# Altium schematic sheet PSU - Power Supply.SchDoc
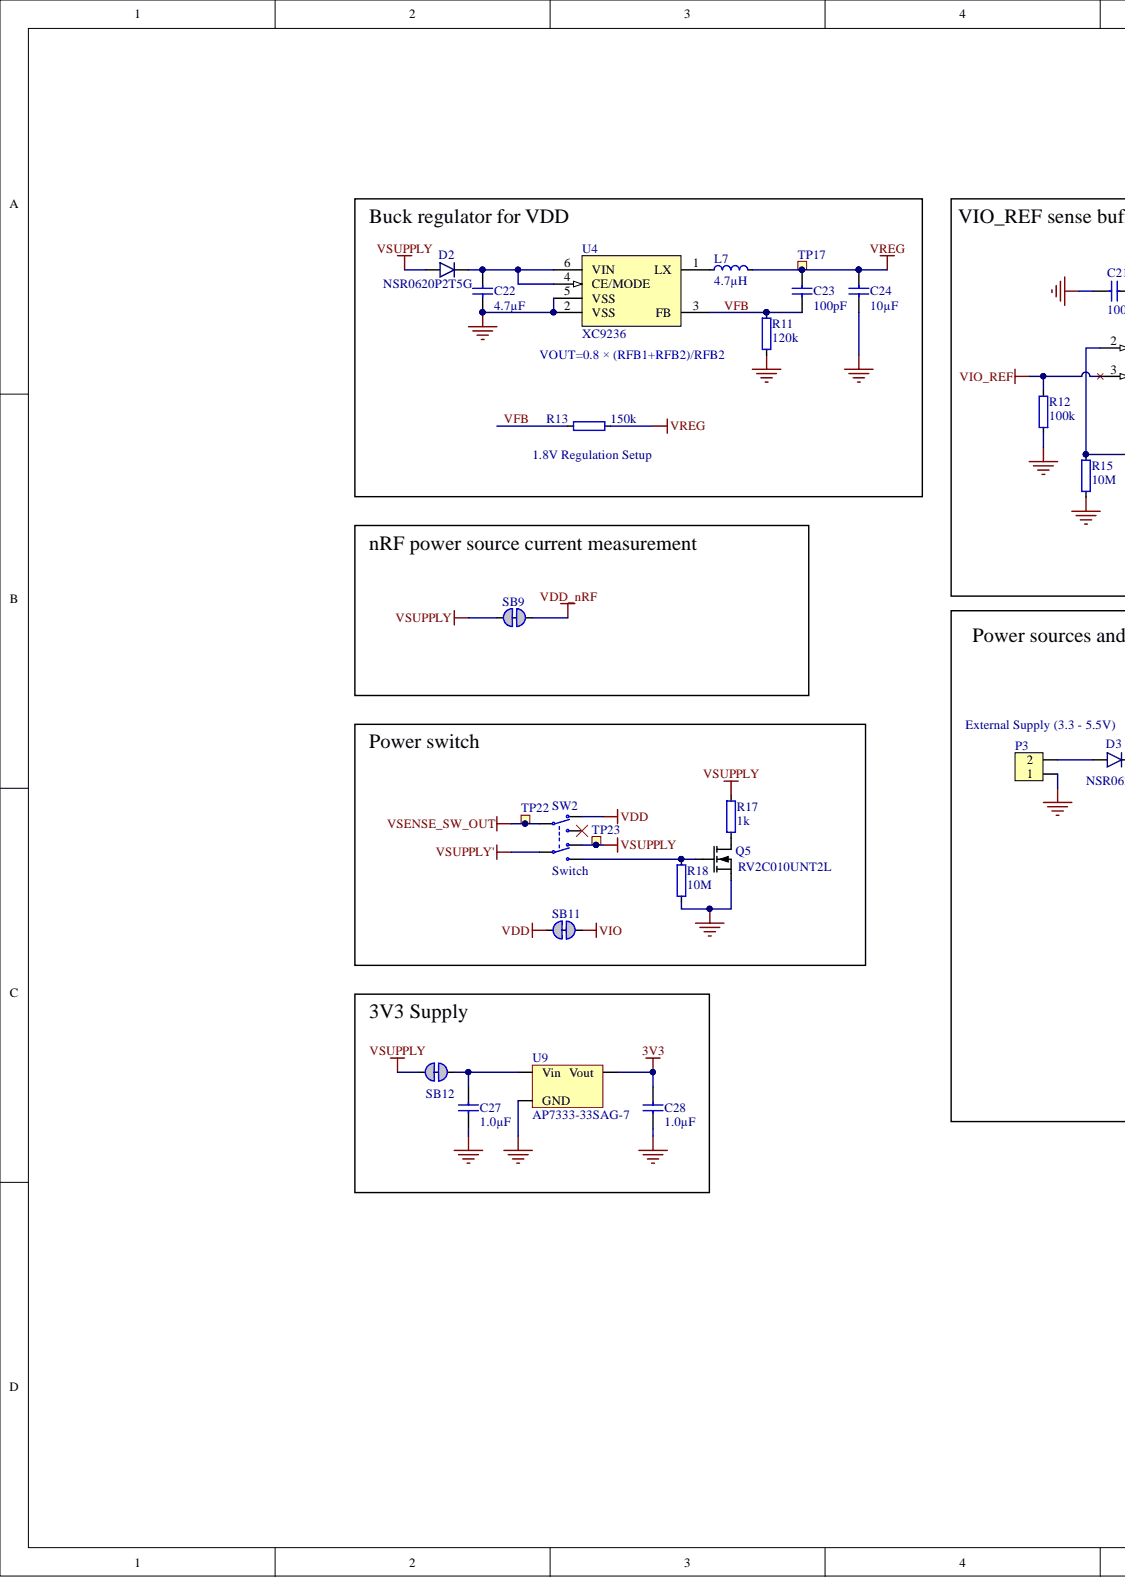
[diagram p0: PSU - Power Supply.SchDoc, left]
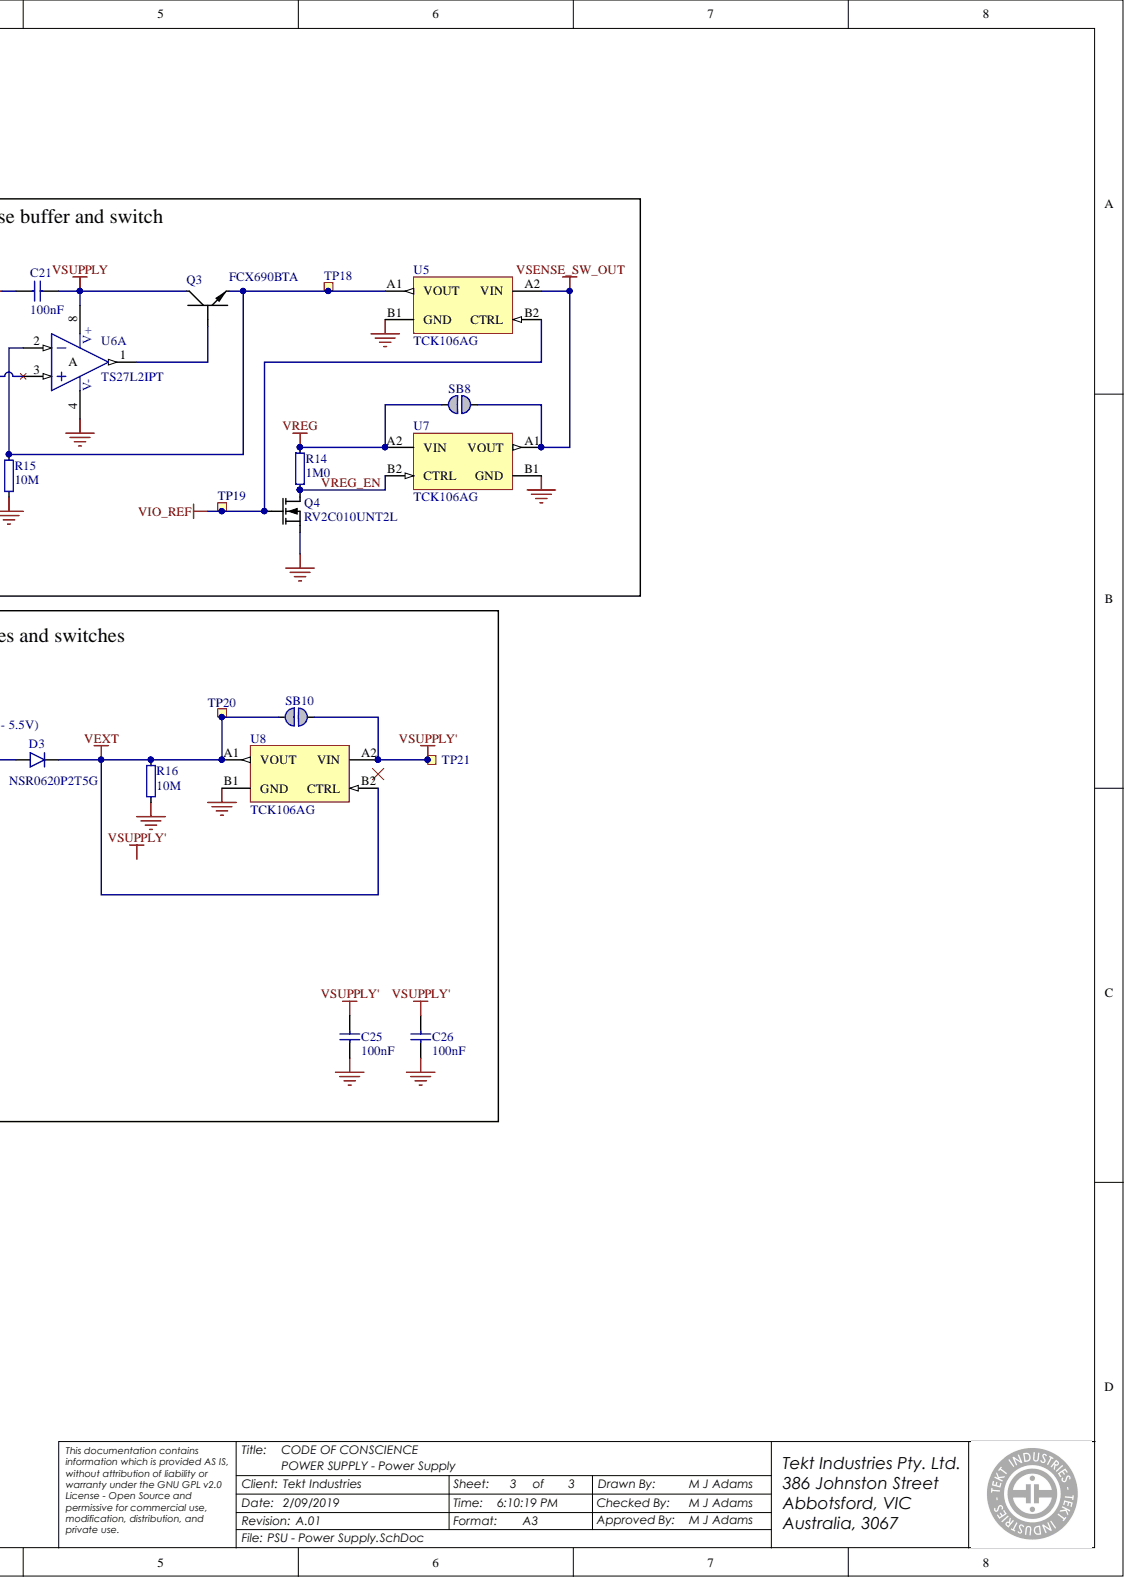
[diagram p1: PSU - Power Supply.SchDoc, right]

## Parts
C21  100nF  [CAPC0603X03L_C]
C22  4.7µF  [CAPC1005X04L]
C23  100pF  [CAPC0603X03L_C]
C24  10µF  [CAPC1608X06L]
C25  100nF  [CAPC0603X03L_C]
C26  100nF  [CAPC0603X03L_C]
C27  1.0µF  [CAPC0603X03L_C]
C28  1.0µF  [CAPC0603X03L_C]
D2  NSR0620P2T5G  [SODFL100X40-2N]
D3  NSR0620P2T5G  [SODFL100X40-2N]
L7  4.7µH  [TY-NR3015]
P3  Pin List 1x2, Angled  [LIST_ANG_1x2-SMD-2.54MM]  (value not drawn)
Q3  FCX690BTA  [SOT89-150P409X160-3N]
Q4  RV2C010UNT2L  [DFN65P100X40-3L]
Q5  RV2C010UNT2L  [DFN65P100X40-3L]
R11  120k  [RESC0603X03L_C]
R12  100k  [RESC0603X03L_C]
R13  150k  [RESC0603X03L_C]
R14  1M0  [RESC0603X03L_C]
R15  10M  [RESC0603X03L_C]
R16  10M  [RESC0603X03L_C]
R17  1k  [RESC0603X03L_C]
R18  10M  [RESC0603X03L_C]
SB8  Solderbridge  [Solderbridge]  (value not drawn)  (no BOM)
SB9  Solderbridge  [Solderbridge_Shorted]  (value not drawn)
SB10  Solderbridge  [Solderbridge]  (value not drawn)  (no BOM)
SB11  Solderbridge  [Solderbridge_Shorted]  (value not drawn)
SB12  Solderbridge  [Solderbridge_Shorted]  (value not drawn)
SW2  Switch  [Switch-Slide-RA-J-Lead-DPDT-8.5x3.5mm]
TP17  Ø1.5mm,SMD  [TP_SMD_Ø1.5mm]  (value not drawn)  (no BOM)
TP18  Ø1.5mm,SMD  [TP_SMD_Ø1.5mm]  (value not drawn)  (no BOM)
TP19  Ø1.5mm,SMD  [TP_SMD_Ø1.5mm]  (value not drawn)  (no BOM)
TP20  Ø1.5mm,SMD  [TP_SMD_Ø1.5mm]  (value not drawn)  (no BOM)
TP21  Ø1.5mm,SMD  [TP_SMD_Ø1.5mm]  (value not drawn)  (no BOM)
TP22  Ø1.5mm,SMD  [TP_SMD_Ø1.5mm]  (value not drawn)  (no BOM)
TP23  Ø1.5mm,SMD  [TP_SMD_Ø1.5mm]  (value not drawn)  (no BOM)
U4  XC9236  [USP-6C]
U5  TCK106AG  [TCK106AG]
U6  TS27L2IPT  [TSOP65P640X120-8N]  (part A)
U7  TCK106AG  [TCK106AG]
U8  TCK106AG  [TCK106AG]
U9  AP7333-33SAG-7  [SOT-23A]

## Nets
3V3: C28.1 U9.2
GND: C21.1 C22.1 C24.1 C25.2 C26.1 C27.2 C28.2 P3.1 Q4.2 Q5.2 R11.1 R12.2 R15.1 R16.1 R18.1 U4.2(VSS) U4.5(VSS) U4.7 U5.B1(GND) U6.4 U7.B1(GND) U8.B1(GND) U9.3
VDD: SB11.2 SW2.3
VDD_nRF: SB9.2
VEXT: D3.1 R16.2 SB10.2 TP20.1 U8.A1(VOUT) U8.B2(CTRL)
VIO: SB11.1
VIO_REF: Q4.1 R12.1 TP19.1 U5.B2(CTRL) U6.3
VREG: C23.2 C24.2 L7.2 R13.2 R14.2 SB8.2 TP17.1 U7.A2(VIN)
VSENSE_SW_OUT: SB8.1 SW2.2 TP22.1 U5.A2(VIN) U7.A1(VOUT)
VSUPPLY: C21.2 D2.2 Q3.2 R17.2 SB9.1 SB12.2 SW2.6 TP23.1 U6.8
VSUPPLY': C25.1 C26.2 SB10.1 SW2.5 TP21.1 U8.A2(VIN)
VFB: C23.1 R11.2 R13.1 U4.3(FB)
VREG_EN: Q4.3 R14.1 U7.B2(CTRL)
NetC22_2: C22.2 D2.1 U4.4(CE/MODE) U4.6(VIN)
NetC27_1: C27.1 SB12.1 U9.1
NetD3_2: D3.2 P3.2
NetL7_1: L7.1 U4.1(LX)
NetQ3_1: Q3.1 U6.1
NetQ3_3: Q3.3 R15.2 TP18.1 U5.A1(VOUT) U6.2
NetQ5_1: Q5.1 R18.2 SW2.4
NetQ5_3: Q5.3 R17.1

Not connected: SW2 1

## Library data of the parts
C21 C25 C26 = C0201-100n: Capacitor, X5R, ±10%; Manufacturer: Murata Electronics North America; Manufacturer Part No.: GRM033R61C104KE84D; Part number IPS: 00000001248; Artikkelnr Noca: N.A.; Database Table Name: Capacitors$; Note: 16V; Component Kind: Standard; ComponentLink1Description: Datasheet; ComponentLink2Description: Supplier link; Footprint Doc: 0201; LatestRevisionDate: 2017-11-09; Library Name: Nordic Passive DB.DbLib; Part Number: C0201-100n; Pin Count: 2; Published: 2014-11-13; Supplier: Digi-Key; Supplier Part No.: 490-9985-1-ND; Value: 100nF; Publisher: Nordic Semiconductor
C22 = C0402-4µ7: Capacitor, X5R, ±20%; Manufacturer: Murata Electronics North America; Manufacturer Part No.: ZRB15XR61A475KE01D; Part number IPS: 00000001251; Artikkelnr Noca: 20039482; Database Table Name: Capacitors$; Note: 10V; Component Kind: Standard; ComponentLink1Description: Datasheet; ComponentLink2Description: Supplier link; Footprint Doc: 0402; LatestRevisionDate: 2017-11-09; Library Name: Nordic Passive DB.DbLib; Part Number: C0402-4µ7; Pin Count: 2; Published: 2009-05-08; Supplier: Digi-Key; Supplier Part No.: 490-13252-1-ND; Value: 4.7µF; Publisher: Nordic Semiconductor
C23 = C0201-100p: Capacitor, NP0, ±2%; Manufacturer: Murata Electronics North America; Manufacturer Part No.: GRM0335C1E101JA01D; Part number IPS: 00000007853; Artikkelnr Noca: N.A.; Database Table Name: Capacitors$; Note: 25V; Component Kind: Standard; ComponentLink1Description: Datasheet; ComponentLink2Description: Supplier link; Footprint Doc: 0201; LatestRevisionDate: 2017-11-09; Library Name: Nordic Passive DB.DbLib; Part Number: C0201-100p; Pin Count: 2; Published: 2014-11-13; Supplier: Digi-Key; Supplier Part No.: 490-3160-1-ND; Value: 100pF; Publisher: Nordic Semiconductor
C24 = C0603-10µF-25V: Capacitor, 0603, 25V, X5R; Manufacturer: Murata Electronics North America; Manufacturer Part No.: ZRB18AR61E106ME01L; Part number IPS: N.A.; Artikkelnr Noca: 20039436; Database Table Name: Capacitors$; Component Kind: Standard; ComponentLink1Description: Datasheet; ComponentLink2Description: Supplier link; Footprint Doc: 0603; LatestRevisionDate: 2015-02-25; Library Name: Nordic Passive DB.DbLib; Note: 25V; Part Number: C0603-10µF-25V; Pin Count: 2; Published: 2015-02-25; Supplier: Digi-Key; Supplier Part No.: 490-10991-1-ND; Value: 10µF; Publisher: Nordic Semiconductor
C27 C28 = C0201-1µ0: Capacitor, X5R, ±10%; Manufacturer: Samsung Electro-Mechanics; Manufacturer Part No.: CL03A105KP3NSNC; Artikkelnr Noca: N.A.; Database Table Name: Capacitors$; Note: 10V; Component Kind: Standard; ComponentLink1Description: Datasheet; ComponentLink2Description: Supplier link; Footprint Doc: 0201; LatestRevisionDate: 2016-08-22; Library Name: Nordic Passive DB.DbLib; Part Number: C0201-1µ0; Part number IPS: N.A.; Pin Count: 2; Published: 2009-05-08; Supplier: Digi-Key; Supplier Part No.: 1276-6795-1-ND; Value: 1.0µF; Publisher: Nordic Semiconductor
D2 D3 = NSR0620P2T5G: 20V schottky barrier diode, Vf 350 mV @ 100 mA, Ir 2.0 µA @ 10 V, If 500 mA; Manufacturer: ON Semiconductor; Part number IPS: N.A.; Artikkelnr Noca: 10029169; Database Table Name: Semiconductors$; Component Kind: Standard; ComponentLink1Description: Datasheet; ComponentLink2Description: Supplier link; Footprint Doc: SOD-923; HelpURL: http://www.onsemi.com/pub_link/Collateral/NSR0620P2-D.PDF; LatestRevisionDate: 2016-07-07; Library Name: Nordic Discrete DB.DbLib; Manufacturer Part No.: NSR0620P2T5G; Part Number: NSR0620P2T5G; Pin Count: 2; Published: 2016-07-07; Supplier: Digi-Key; Supplier Part No.: NSR0620P2T5GOSCT-ND; Value: NSR0620P2T5G; Publisher: Nordic Semiconductor
L7 = NR3015-4µ7-1.04A-144mOhm: Ferrite Inductor, Shielded, 1,04A, 144mOhm; Manufacturer: Taiyo Yuden; Manufacturer Part No.: NR3015T4R7M; Part number IPS: N.A.; Artikkelnr Noca: 33029551; Database Table Name: Inductors$; Component Kind: Standard; ComponentLink1Description: Datasheet; ComponentLink2Description: Supplier link; Footprint Doc: 1212; HelpURL: http://www.yuden.co.jp/ut/product/category/inductor/NR3015T4R7M.pdf; LatestRevisionDate: 2014-01-22; Library Name: Nordic Passive DB.DbLib; Part Number: NR3015-4µ7-1.04A-144mOhm; Pin Count: 2; Published: 2014-01-22; Supplier: Digi-Key; Supplier 2: Mouser; Supplier Part No.: 587-1649-1-ND; Supplier Part Number 2: 963-NR3015T4R7M; Value: 4.7µH; Publisher: Nordic Semiconductor
P3 = Pin List Ang 1x2 SMD, 2.54mm: Pin List 1x2, 2.54mm (100mil), Right Angled, SMD; Manufacturer: Samtec Inc.; Manufacturer Part No.: TSM-102-01-L-SH-A; Part number IPS: N.A.; Artikkelnr Noca: 55010023; Database Table Name: Connectors$; Component Kind: Standard; ComponentLink1Description: Datasheet; ComponentLink2Description: Supplier link; Footprint Doc: LIST_ANG_1x2-SMD-2.54MM; HelpURL: \\hizz\pro\app9717\Datasheet\connectores\W-CON\1125B-11XXXXZXXXXX.pdf; LatestRevisionDate: 2016-05-26; Library Name: Nordic Passive DB.DbLib; Part Number: Pin List Ang 1x2 SMD, 2.54mm; Pin Count: 2; Published: 2016-01-22; Supplier: Digi-Key; Supplier 2: Samtec; Supplier Part No.: SAM10266-ND; Supplier Part Number 2: TSM-102-01-L-SH-A; Value: Pin List 1x2, Angled; Publisher: Nordic Semiconductor
Q3 = FCX690BTA: 45V NPN high gain power transistor; Manufacturer: Diodes Incorporated; Part number IPS: N.A.; Artikkelnr Noca: 35010019; Database Table Name: Semiconductors$; Component Kind: Standard; ComponentLink1Description: Datasheet; ComponentLink2Description: Supplier link; Footprint Doc: SOT-89; HelpURL: http://www.diodes.com/_files/datasheets/FCX690B.pdf; LatestRevisionDate: 2016-07-07; Library Name: Nordic Discrete DB.DbLib; Manufacturer Part No.: FCX690BTA; Part Number: FCX690BTA; Pin Count: 3; Published: 2016-07-07; Supplier: Digi-Key; Supplier Part No.: FCX690BCT-ND; Value: FCX690BTA; Publisher: Nordic Semiconductor
Q4 Q5 = RV2C010UNT2L: N-Channel MOSFET, 20V, 1A, 470mOhm, 400mW; Manufacturer: Rohm Semiconductor; Part number IPS: N.A.; Artikkelnr Noca: 35010020; Database Table Name: Semiconductors$; Component Kind: Standard; ComponentLink1Description: Datasheet; ComponentLink2Description: Supplier link; Footprint Doc: DFN-3; HelpURL: http://rohmfs.rohm.com/en/products/databook/datasheet/discrete/transistor/mosfet/rv2c010unt2l-e.pdf; LatestRevisionDate: 2016-08-09; Library Name: Nordic Discrete DB.DbLib; Manufacturer Part No.: RV2C010UNT2L; Part Number: RV2C010UNT2L; Pin Count: 3; Published: 2016-08-09; Supplier: Digi-Key; Supplier 2: Mouser; Supplier Part No.: RV2C010UNT2LCT-ND; Supplier Part Number 2: 755-RV2C010UNT2L; Value: RV2C010UNT2L; Publisher: Nordic Semiconductor
R11 = R0201-120k: Resistor, ±1%, 0.05W; Manufacturer: Yageo; Manufacturer Part No.: RC0201FR-07120KL; Artikkelnr Noca: N.A.; Database Table Name: Resistors$; Note: Chip Res 1%; Component Kind: Standard; ComponentLink1Description: Datasheet; ComponentLink2Description: Supplier link; Footprint Doc: 0201; LatestRevisionDate: 2014-11-13; Library Name: Nordic Passive DB.DbLib; Part Number: R0201-120k; Part number IPS: N.A.; Pin Count: 2; Published: 2014-11-13; Supplier: Digi-Key; Supplier Part No.: 311-120KMCT-ND; Value: 120k; Publisher: Nordic Semiconductor
R12 = R0201-100k: Resistor, ±1%, 0.05W; Manufacturer: Yageo; Manufacturer Part No.: RC0201FR-07100KL; Artikkelnr Noca: N.A.; Database Table Name: Resistors$; Note: Chip Res 1%; Component Kind: Standard; ComponentLink1Description: Datasheet; ComponentLink2Description: Supplier link; Footprint Doc: 0201; LatestRevisionDate: 2014-11-13; Library Name: Nordic Passive DB.DbLib; Part Number: R0201-100k; Part number IPS: N.A.; Pin Count: 2; Published: 2014-11-13; Supplier: Digi-Key; Supplier Part No.: 311-100KMCT-ND; Value: 100k; Publisher: Nordic Semiconductor
R13 = R0201-150k: Resistor, ±1%, 0.05W; Manufacturer: Stackpole Electronics Inc; Manufacturer Part No.: RMCF0201FT150K; Artikkelnr Noca: N.A.; Database Table Name: Resistors$; Note: Chip Res 1%; Component Kind: Standard; ComponentLink1Description: Datasheet; ComponentLink2Description: Supplier link; Footprint Doc: 0201; LatestRevisionDate: 2014-11-13; Library Name: Nordic Passive DB.DbLib; Part Number: R0201-150k; Part number IPS: N.A.; Pin Count: 2; Published: 2014-11-13; Supplier: Digi-Key; Supplier Part No.: RMCF0201FT150KCT-ND; Value: 150k; Publisher: Nordic Semiconductor
R14 = R0201-1M0: Resistor, ±1%, 0.05W; Manufacturer: Yageo; Manufacturer Part No.: RC0201FR-071ML; Artikkelnr Noca: N.A.; Database Table Name: Resistors$; Note: Chip Res 1%; Component Kind: Standard; ComponentLink1Description: Datasheet; ComponentLink2Description: Supplier link; Footprint Doc: 0201; LatestRevisionDate: 2014-11-13; Library Name: Nordic Passive DB.DbLib; Part Number: R0201-1M0; Part number IPS: N.A.; Pin Count: 2; Published: 2014-11-13; Supplier: Digi-Key; Supplier Part No.: 311-1MMCT-ND; Value: 1M0; Publisher: Nordic Semiconductor
R15 R16 R18 = R0201-10M: Resistor, ±1%, 0.05W; Manufacturer: KOA Speer Electronics, Inc.; Manufacturer Part No.: RK73H1HTTC1005F; Artikkelnr Noca: N.A.; Database Table Name: Resistors$; Note: Chip Res 1%; Component Kind: Standard; ComponentLink1Description: Datasheet; ComponentLink2Description: Supplier link; Footprint Doc: 0201; LatestRevisionDate: 2014-11-13; Library Name: Nordic Passive DB.DbLib; Part Number: R0201-10M; Part number IPS: N.A.; Pin Count: 2; Published: 2014-11-13; Supplier: Digi-Key; Supplier Part No.: 2019-RK73H1HTTC1005FCT-ND; Value: 10M; Publisher: Nordic Semiconductor
R17 = R0201-1k0: Resistor, ±1%, 0.05W; Manufacturer: Yageo; Manufacturer Part No.: RC0201FR-071KL; Artikkelnr Noca: N.A.; Database Table Name: Resistors$; Note: Chip Res 1%; Component Kind: Standard; ComponentLink1Description: Datasheet; ComponentLink2Description: Supplier link; Footprint Doc: 0201; LatestRevisionDate: 2014-11-13; Library Name: Nordic Passive DB.DbLib; Part Number: R0201-1k0; Part number IPS: N.A.; Pin Count: 2; Published: 2014-11-13; Supplier: Digi-Key; Supplier Part No.: 311-1KMCT-ND; Value: 1k; Publisher: Nordic Semiconductor
SB8 SB10 = Solderbridge: Artikkelnr Noca: N.A.; Database Table Name: Connectors$; ComponentLink1Description: Datasheet; ComponentLink2Description: Supplier link; Description: Solderbridge; Footprint Doc: Solderbridge; LatestRevisionDate: 2009-12-14; Library Name: Nordic Passive DB.DbLib; Part Number: Solderbridge; Part number IPS: N.A.; Pin Count: 2; Published: 2009-12-14; Value: Solderbridge; Publisher: Nordic Semiconductor
SB9 SB11 SB12 = Solderbridge Shorted: Shorted Solderbridge; Artikkelnr Noca: N.A.; Database Table Name: Connectors$; Component Kind: Net Tie; ComponentLink1Description: Datasheet; ComponentLink2Description: Supplier link; Footprint Doc: Solderbridge_Shorted; LatestRevisionDate: 2012-08-07; Library Name: Nordic Passive DB.DbLib; Part Number: Solderbridge Shorted; Part number IPS: N.A.; Pin Count: 2; Published: 2012-08-07; Value: Solderbridge; Publisher: Nordic Semiconductor
SW2 = Switch-Slide-RA-J-Lead-DPDT-8.5x3.5mm_C&K: SMD slide Switch (ON-ON); Manufacturer: C&K Components; Manufacturer Part No.: JS202011JAQN; Part number IPS: 00000007811; Artikkelnr Noca: N.A.; Database Table Name: Rele_Switch$; Component Kind: Standard; ComponentLink1Description: Datasheet; ComponentLink2Description: Supplier link; Footprint Doc: Switch-Slide-RA-J-Lead-DPDT-8.5x3.5mm; HelpURL: http://www.ck-components.com/media/1422/js.pdf; LatestRevisionDate: 2017-02-01; Library Name: Nordic Discrete DB.DbLib; Part Number: Switch-Slide-RA-J-Lead-DPDT-8.5x3.5mm_C&K; Pin Count: 6; Published: 2016-09-15; Supplier: Digi-Key; Supplier 2: Mouser; Supplier Part No.: CKN10722CT-ND; Supplier Part Number 2: 611-JS202011JAQN; Value: Switch; Publisher: Nordic Semiconductor
TP17-TP23 = TP_SMD_Ø1.5MM: 1.0mm circular SMD testpad; Artikkelnr Noca: N.A.; Database Table Name: Connectors$; ComponentLink1Description: Datasheet; ComponentLink2Description: Supplier link; Footprint Doc: TP_SMD_Ø1.5mm; LatestRevisionDate: 2016-06-02; Library Name: Nordic Passive DB.DbLib; Part Number: TP_SMD_Ø1.5MM; Part number IPS: N.A.; Pin Count: 1; Published: 2016-06-02; Value: Ø1.5mm,SMD; Publisher: Nordic Semiconductor
U4 = XC9236F08CER-G: 600mA Driver Transistor Built-In, Synchronous Step-Down DC/DC Converters; Manufacturer: Torex Semiconductor; Artikkelnr Noca: N.A.; Database Table Name: Misc IC$; Component Kind: Standard; ComponentLink1Description: Datasheet; ComponentLink2Description: Supplier link; Footprint Doc: USP-6C; HelpURL: http://www.torexsemi.com/products/dcdc_converters/data/XC9235~37.pdf; LatestRevisionDate: 2017-11-15; Library Name: Nordic Active DB.DbLib; Manufacturer Part No.: XC9236F08CER-G; Part Number: XC9236F08CER-G; Part number IPS: N.A.; Pin Count: 6; Published: 2016-08-08; Supplier: Mouser; Supplier Part No.: 865-XC9236F08CER-G; Value: XC9236; Publisher: Nordic Semiconductor
U5 U7 U8 = TCK106AG: IC POWER DIST LOAD SWITCH 4WCSP; Manufacturer: Toshiba; Manufacturer Part No.: TCK106AG,LF; Artikkelnr Noca: N.A.; Database Table Name: Misc IC$; Component Kind: Standard; ComponentLink1Description: Datasheet; ComponentLink1URL: https://toshiba.semicon-storage.com/info/docget.jsp?did=30131&prodName=TCK106AG; ComponentLink2Description: Supplier link; Footprint Doc: 4-WCSPD; LatestRevisionDate: 2019-02-17; Library Name: Nordic Active DB.DbLib; Part Number: TCK106AG; Part number IPS: N.A.; Pin Count: 4; Published: 2019-02-17; Supplier: Digi-Key; Supplier 2: Mouser; Supplier Part No.: TCK106AGLFTR-ND; Supplier Part Number 2: 757-TCK106AGLF; Value: TCK106AG; Publisher: Nordic Semiconductor
U6 = TS27L2IPT: Precision very low power CMOS dual operational amplifier; Manufacturer: STMicroelectronics; Part number IPS: N.A.; Artikkelnr Noca: 17010019; Database Table Name: Misc IC$; Component Kind: Standard; ComponentLink1Description: Datasheet; ComponentLink2Description: Supplier link; Footprint Doc: TSSOP-8; HelpURL: http://www.st.com/content/ccc/resource/technical/document/datasheet/38/5b/ca/bd/c0/26/4a/34/CD00000880.pdf/files/CD00000880.pdf/jcr:content/translations/en.CD00000880.pdf; LatestRevisionDate: 2016-07-07; Library Name: Nordic Active DB.DbLib; Manufacturer Part No.: TS27L2IPT; Part Number: TS27L2IPT; Pin Count: 8; Published: 2016-07-07; Supplier: Digi-Key; Supplier Part No.: 497-8085-1-ND; Value: TS27L2IPT; Publisher: Nordic Semiconductor
U9 = AP7333-33SAG-7: 300mA, Low Quiescent Current, Fast Transient Low Dropout Linear Regulator; Manufacturer: Diodes Incorporated; Part number IPS: N.A.; Artikkelnr Noca: 17039216; Database Table Name: Misc IC$; Component Kind: Standard; ComponentLink1Description: Datasheet; ComponentLink2Description: Supplier link; Footprint Doc: SOT-23-3; HelpURL: http://www.diodes.com/datasheets/AP7333.pdf; LatestRevisionDate: 2012-06-15; Library Name: Nordic Active DB.DbLib; Manufacturer Part No.: AP7333-33SAG-7; Part Number: AP7333-33SAG-7; Pin Count: 3; Published: 2012-06-15; Supplier: Digi-Key; Supplier Part No.: AP7333-33SAG-7DICT-ND; Value: AP7333-33SAG-7; Publisher: Nordic Semiconductor
